# Altium schematic sheet RF_Power.SchDoc(RF_Power)
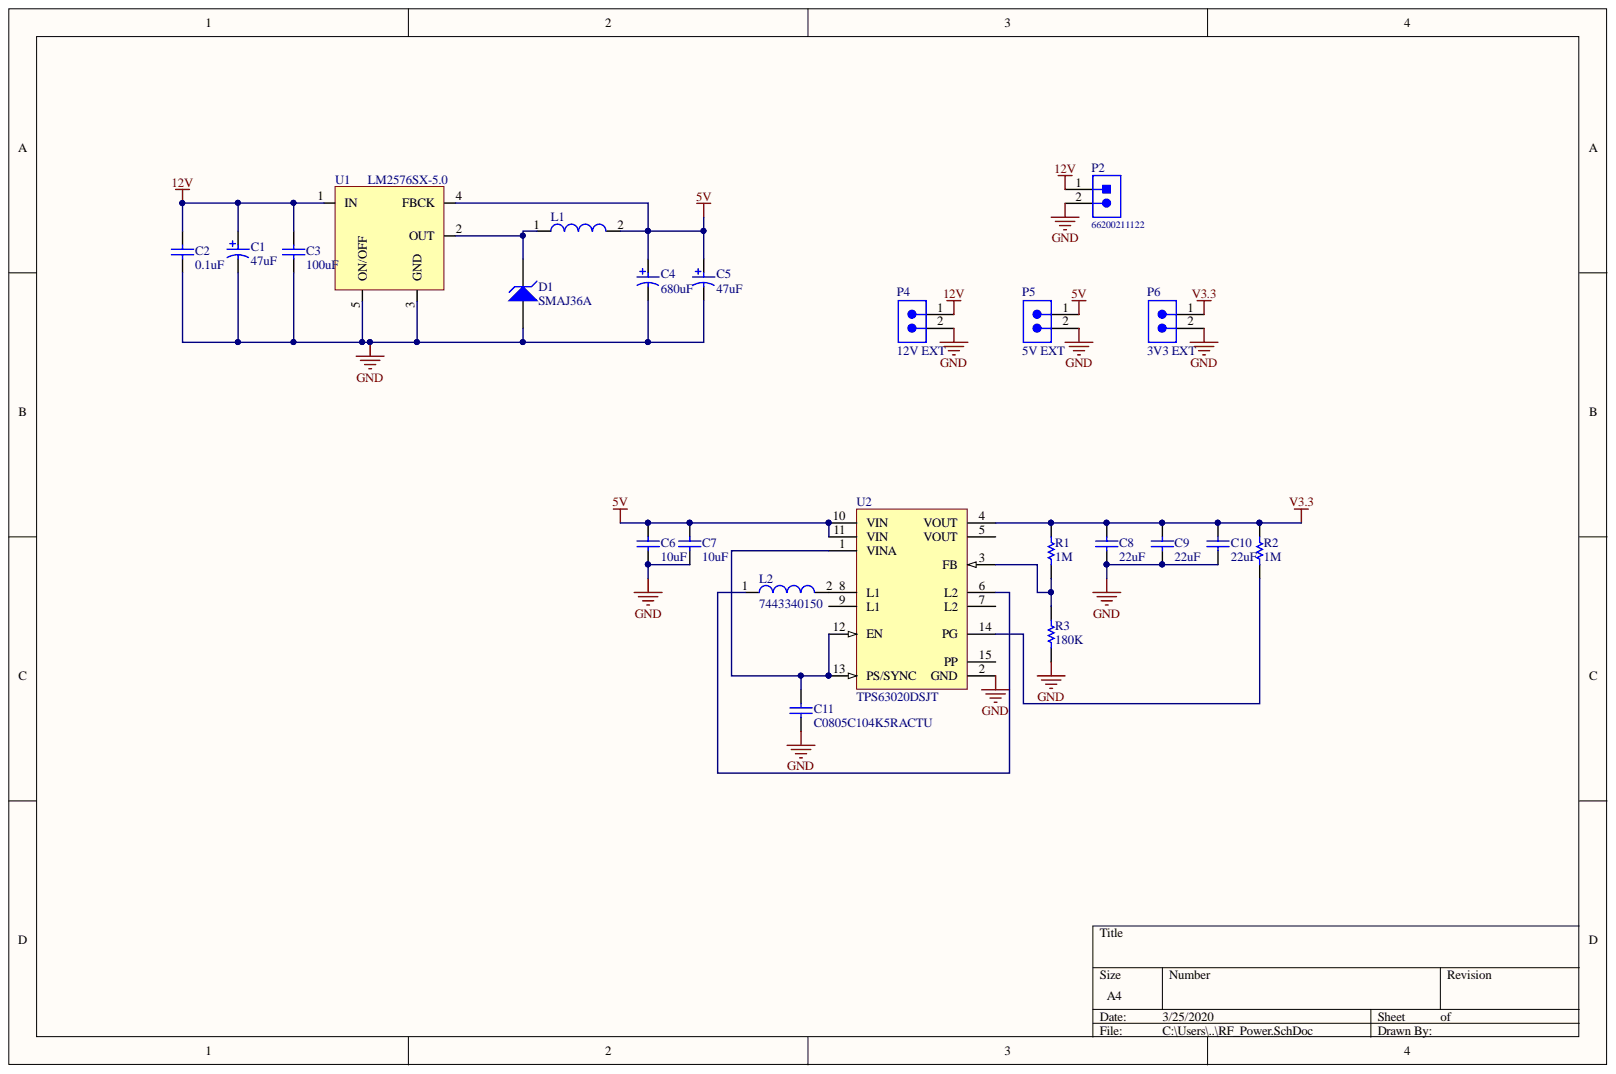
[diagram p0: RF_Power.SchDoc]

## Parts
C1  47uF  [PNSC-FP-V-D_V]
C2  0.1uF  [CAPC1608X90X35ML10T15]
C3  100uF  [MURA-1210_H_2.7_M]
C4  680uF  [NIC-CAPA2-10X10_V]
C5  47uF  [PNSC-FP-V-D_V]
C6  10uF  [CAPC1608X90X35NL10T15]
C7  10uF  [CAPC1608X90X35NL10T15]
C8  22uF  [CAPC2013X140X45NL15T25]
C9  22uF  [CAPC2013X140X45NL15T25]
C10  22uF  [CAPC2013X140X45NL15T25]
C11  C0805C104K5RACTU  [CAPC2013X88X50NL20T25]
D1  SMAJ36A  [LFUS-DO-214AC-2_L]
L1  7447709101  [WE-PD-XXL]  (value not drawn)
L2  7443340150  [WE-HCC-8070]
P2  66200211122  [66200211122]
P4  12V EXT  [SMTC-TSW-102-26-XX-S]
P5  5V EXT  [SMTC-TSW-102-26-XX-S]
P6  3V3 EXT  [SMTC-TSW-102-26-XX-S]
R1  1M  [RESC2013X50X30ML20T20]
R2  1M  [RESC2013X50X30ML20T20]
R3  180K  [RESC1608X55X30LL15T15]
U1  LM2576SX-5.0  [TO263-5]
U2  TPS63020DSJT  [DSJ14-4000X1580TP_V]

## Nets
5V: C4.1 C5.1 C6.2 C7.2 L1.2 P5.1 U1.4(FBCK) U2.10(VIN) U2.11(VIN)
12V: C1.1 C2.2 C3.2 P2.1 P4.1 U1.1(IN)
GND: C1.2 C2.1 C3.1 C4.2 C5.2 C6.1 C7.1 C8.1 C9.1 C10.1 C11.1 D1.1 P2.2 P4.2 P5.2 P6.2 R3.1 U1.3(GND) U1.5(ON/OFF) U2.2(GND)
V3.3: C8.2 C9.2 C10.2 P6.1 R1.2 R2.2 U2.4(VOUT)
NetC11_2: C11.2 U2.1(VINA) U2.12(EN) U2.13(PS/SYNC)
NetD1_2: D1.2 L1.1 U1.2(OUT)
NetL2_1: L2.1 U2.6(L2)
NetL2_2: L2.2 U2.8(L1)
NetR1_1: R1.1 R3.2 U2.3(FB)
NetR2_1: R2.1 U2.14(PG)

Not connected: U2 5(VOUT) 7(L2) 9(L1) 15(PP)

## Library data of the parts
C1 C5 = CMP-2000-06216-1: Aluminum Electrolytic Capacitor, 47 uF, +/- 20%, 35 V, 2-Pin SMD (Size Code D), -55 to 105 degC, RoHS, Tape and Reel; Manufacturer: Panasonic; Type: Can; Ripple Current: 300mA; Rated Voltage: 35V; Lifetime@Temperature: 2000Hrs@105°C; ESR (Equivalent Series Resistance): 0.26O; Capacitance: 47µF; Series: FP; Package URL: http://industrial.panasonic.com/cdbs/www-data/pdf/RDE0000/DME0000COL48.pdf; Package Reference: FP-V-D; Package Description: 2-Pin Electrolytic Aluminum Capacitor, Body 6.6 x 6.6 mm; Operating Temp Range: -55to+105°C; Operating Temp-Max: 105°C; Mounting Technology: SurfaceMount; Manufacturer URL: http://www.panasonic.com/; Library Name: Altium Content Vault; Datasheet Version: 03/2016; Datasheet URL: http://industrial.panasonic.com/cdbs/www-data/pdf/RDE0000/ABA0000C1184.pdf; Component Kind: Standard; Case Size/Dimension: Ø6.3x5.8mm
C2 = CMP-2000-04945-1: Chip Capacitor, 22 pF, +/- 5%, 50 V, -55 to 125 degC, 0603 (1608 Metric), RoHS, Tape and Reel; Manufacturer: Murata; Rated Voltage: 50V; Dielectric: C0G; Capacitance: 22pF; Tolerance (%): ±5%; Package Reference: 603; Package Description: 2-Pin Surface Mount Device, Body 1.6 x 0.8 mm; Manufacturer URL: http://www.murata.com/; Library Name: Altium Content Vault; Datasheet Version: Jul-06; Datasheet URL: https://datasheet.ciiva.com/11786/0900766b807a1cab-11786398.pdf; Component Kind: Standard; Case Size/Dimension: 0603
C3 = CMP-2000-05259-1: CAP, Ceramic, 100 uF, 20%, 6.3 V, 1210, RoHS, Tape and Reel; Manufacturer: MurataElectronics; Voltage: 6.3Vdc; Type: Flat; Rad Hard: No; Product Length: 3.2mm; Product Height: 2.5mm; Dielectric: X5R; Tolerance: 20%; Product Depth: 2.5mm; Package Reference: 1210; Package Description: 2-Pin Surface Mount Device, Body 3.2 x 2.5 mm; Package/Case: 1210; Operating Temperature: -55to85degC; Mounting: SurfaceMount; Manufacturer URL: http://www.murata.com/; Library Name: Altium Content Vault; Datasheet Version: Dec-10; Datasheet URL: https://datasheet.ciiva.com/29199/455114-da-01-en-vs-kondensator-1210-29199468.pdf; Construction: Flat; Component Kind: Standard; Category: CapacitorCeramicMultilayer; Capacitance Value: 100uF
C4 = CMP-0687-00005-1: Aluminum Electrolytic Capacitor, UD Series, Low Impedance, 680 uF, 16 V, + / - 20%, -55 to 105 degC, Chip Type, 10 x 10 mm D x L, Pb-Free, Reel; PartNumber: UUD1C681MNL1GS; Manufacturer: Nichicon; Tolerance Rated Capacitance: -20 to 20%; Rated Voltage: 16 V; Rated Ripple Current (at 100kHz): 670 mA; Packing: Dia382mm Reel; Impedance: 0.09 Ohm; Endurance Time: 5000 h; RoHS: TRUE; Rated Capacitance: 680 uF; PackageReference: CAPAE-D10H10; PackageDescription: 2-Pin Surface Mount Device, Diameter 10 mm, Height 10 mm, Pitch 8 mm; Mounting Technology: Surface Mount; Library Name: Altium Content Vault; Length: 10 mm; Diameter: 10 mm; DatasheetVersion: 08/2012; ComponentLink3URL: http://products.nichicon.co.jp/en/pdf/XJA043/e-ud.pdf; ComponentLink3Description: Package Specification; ComponentLink2URL: http://products.nichicon.co.jp/en/pdf/XJA043/e-ud.pdf; ComponentLink2Description: Datasheet; ComponentLink1URL: http://www.nichicon.co.jp/; ComponentLink1Description: Manufacturer URL; Component Kind: Standard
C6 C7 = CMP-2006-02792-1: Part Number: GRM188R60J106ME47D; Manufacturer: Murata; Library Name: Altium Content Vault; Component Kind: Standard
C8-C10 = CMP-2007-03385-1: Part Number: GRM21BR60J226ME39L; Manufacturer: Murata; Library Name: Altium Content Vault; Component Kind: Standard
C11 = CMP-2007-00279-1: Manufacturer: Kemet; Part Number: C0805C104K5RACTU; Library Name: Altium Content Vault; Component Kind: Standard
D1 = CMP-2000-07089-1: Transient Voltage Suppression Diode, 400 W, 36 V, -65 to 150 degC, 2-Pin SMD, RoHS, Tape and Reel; Manufacturer: Littelfuse; Power Dissipation: 400W; Directional: Uni-Directional; Clamping Voltage-Max: 58.1V; Breakdown Voltage-Min: 40V; Breakdown Voltage-Max: 44.2V; Package Reference: DO-214AC; Package Description: 2-Pin Surface Mount Device, Body 4.295 x 2.595 mm; Mounting Technology: SurfaceMount; Manufacturer URL: http://www.littelfuse.com/; Library Name: Altium Content Vault; Datasheet Version: 11/2015; Datasheet URL: http://www.littelfuse.com/~/media/electronics/datasheets/tvs_diodes/littelfuse_tvs_diode_smaj_datasheet.pdf.pdf; Component Kind: Standard
L1 = CMP-0227-00035-1: SMD-Shielded Power Inductor WE-PD, L = 100 µH; Inductance: 100 ÂµH; PackageVersion: 2004; PackageReference: WE-PD-XXL; PackageDescription: SMD Inductor, 2-Leads, Body 12.0 x 12.0 mm; Library Name: Altium Content Vault; DatasheetVersion: ver 1, Oct-2004; ComponentLink2URL: http://www.we-online.de/katalog/media/pdf/7447709101.pdf; ComponentLink2Description: Datasheet; ComponentLink1URL: http://www.we-online.com; ComponentLink1Description: Manufacturer Link; Component Kind: Standard
L2 = CMP-0226-00098-1: SMD High Current Cube Inductor WE-HCC, L=1.50 µH; Inductance: 1.50 ÂµH; PackageVersion: 2009; PackageReference: WE-HCC-8070; PackageDescription: Inductor, 2-Leads, Body 8.4 x 7.9 mm; Library Name: Altium Content Vault; DatasheetVersion: ver 2, Nov-2009; ComponentLink2URL: http://www.we-online.de/katalog/media/pdf/7443340150.pdf; ComponentLink2Description: Datasheet; ComponentLink1URL: http://www.we-online.com; ComponentLink1Description: Manufacturer Link; Component Kind: Standard
P2 = CMP-1502-00440-1: THT Male Header WR-MPC3, Vertical, Single Row, pitch 3 mm, 2 pins; Manufacturer: Wurth Elektronik; Working Voltage: 250VAC; Pitch: 3mm; Pin Number: 2; Contact Resistance: 10mOhm; Part Number: 66200211122; Packaging: Tube; Package Reference: 66200211122; Orientation: Vertical; Operating Temperature: -40to105degC; Mounting Technology: THT; Manufacturer URL: http://www.we-online.de; Library Name: Altium Content Vault; Gender: Male; Datasheet URL: https://katalog.we-online.de/em/datasheet/6620xx11122.pdf; Component Kind: Standard; Publisher: WurthElektronik
P4-P6 = CMP-1024-00327-1: 0.025" SQ Post Header, Through-hole, Vertical, -55 to 125 degC, 2.54 mm Pitch, 2-Pin, Male, RoHS; PartNumber: TSW-102-26-G-S; Manufacturer: Samtec; Pitch: 2.54 mm; Temperature Range: -55 to 125 degC; RoHS: True; PackageVersion: DM, 8/1988; PackageReference: TSW-102-26-XX-S; PackageDescription: .025[0.64] SQ. Post Terminal Strip Assembly; Orientation: Vertical; Mounting Technology: Through-hole; Library Name: Altium Content Vault; DatasheetVersion: F-214; ComponentLink3URL: http://www.samtec.com/documents/webfiles/cpdf/TSW-XXX-XX-XXX-X-XX-XXX-MKT.pdf; ComponentLink3Description: Package Specification; ComponentLink2URL: http://www.samtec.com/documents/webfiles/pdf/TSW_TH.PDF; ComponentLink2Description: Datasheet; ComponentLink1URL: http://www.samtec.com; ComponentLink1Description: Manufacturer URL; Component Kind: Standard
R1 R2 = CMP-2001-03630-1: Part Number: CRCW08051M00FKEA; Manufacturer: Vishay Dale; Library Name: Altium Content Vault; Component Kind: Standard
R3 = CMP-2000-00296-1: Part Number: ERJ3EKF1803V; Manufacturer: Panasonic; Library Name: Altium Content Vault; Component Kind: Standard
U1 = LM2576SX-5.0: IC REG BUCK 5V 3A TO263-5; Supplier Part Number 1: LM2576SX-5.0/NOPBCT-ND; Supplier 1: Digi-Key; Component Kind: Standard; Library Name: MCB.SchLib
U2 = CMP-2000-07282-1: High Efficiency Single Inductor Buck-Boost Converter, 4 A, 1.8 to 5.5 V Input, 1.2 to 5.5 V Output, -40 to 85 degC, 14-Pin VSON (DSJ), Green (RoHS & no Sb/Br), Tape and Reel; Manufacturer Product Page: TPS63020DSJTSpecifications; Manufacturer: Texas Instruments; Voltage - Output (Min/Fixed): 1.2V; Voltage - Output (Max): 5.5V; Voltage - Input (Min): 1.8V; Synchronous Rectifier: Yes; Reference Design Library: TPS63020EVM-487:3.3V@1A,1.8~5.5Vin; Product Training Modules: TPS63020Overview; PCN Assembly/Origin: Assembly/TestSiteAddition10/Jul/2015; Package Version: 4208212-3/C, 06/2011; Output Type: Adjustable; Output Configuration: Positive; Other Names: 296-27230-6; Online Catalog: Buck-BoostIC; Number of Outputs: 1; Function: Step-Up/Step-Down; Frequency - Switching: 2.4MHz; For Use With: 296-27260-ND-EVALMODFORTPS63020; Featured Product: TPS63020Buck-BoostConverterCreateyourpowerdesignnowwithTI’sWEBENCH®Designer; Current - Output: 3.5A(Switch); Voltage - Input (Max): 5.5V; Topology: Buck-Boost; Supplier Device Package: 14-VSON(4x3); Standard Package: 1; Series: -; Packaging: Digi-Reel®; Package Reference: DSJ14-4000X1580; Package Description: 14-Pin Small Outline No-lead, Body 4 x 3 mm, Pitch 0.5 mm; Package / Case: 14-VFDFNExposedPad; Operating Temperature: -40°C~85°C(TA); Mounting Type: SurfaceMount; Mounting Technology: SurfaceMount; Manufacturer URL: http://www.ti.com/; Library Name: Altium Content Vault; Family: PMIC-VoltageRegulators-DCDCSwitchingRegulators; Datasheet Version: Rev. D, 10/2015; Datasheet URL: http://www.ti.com/general/docs/lit/getliterature.tsp?genericPartNumber=TPS63020&fileType=pdf; Component Kind: Standard; Category: IntegratedCircuits(ICs)
